# Altium schematic sheet 02_MSP430.SchDoc(02_MSP430)
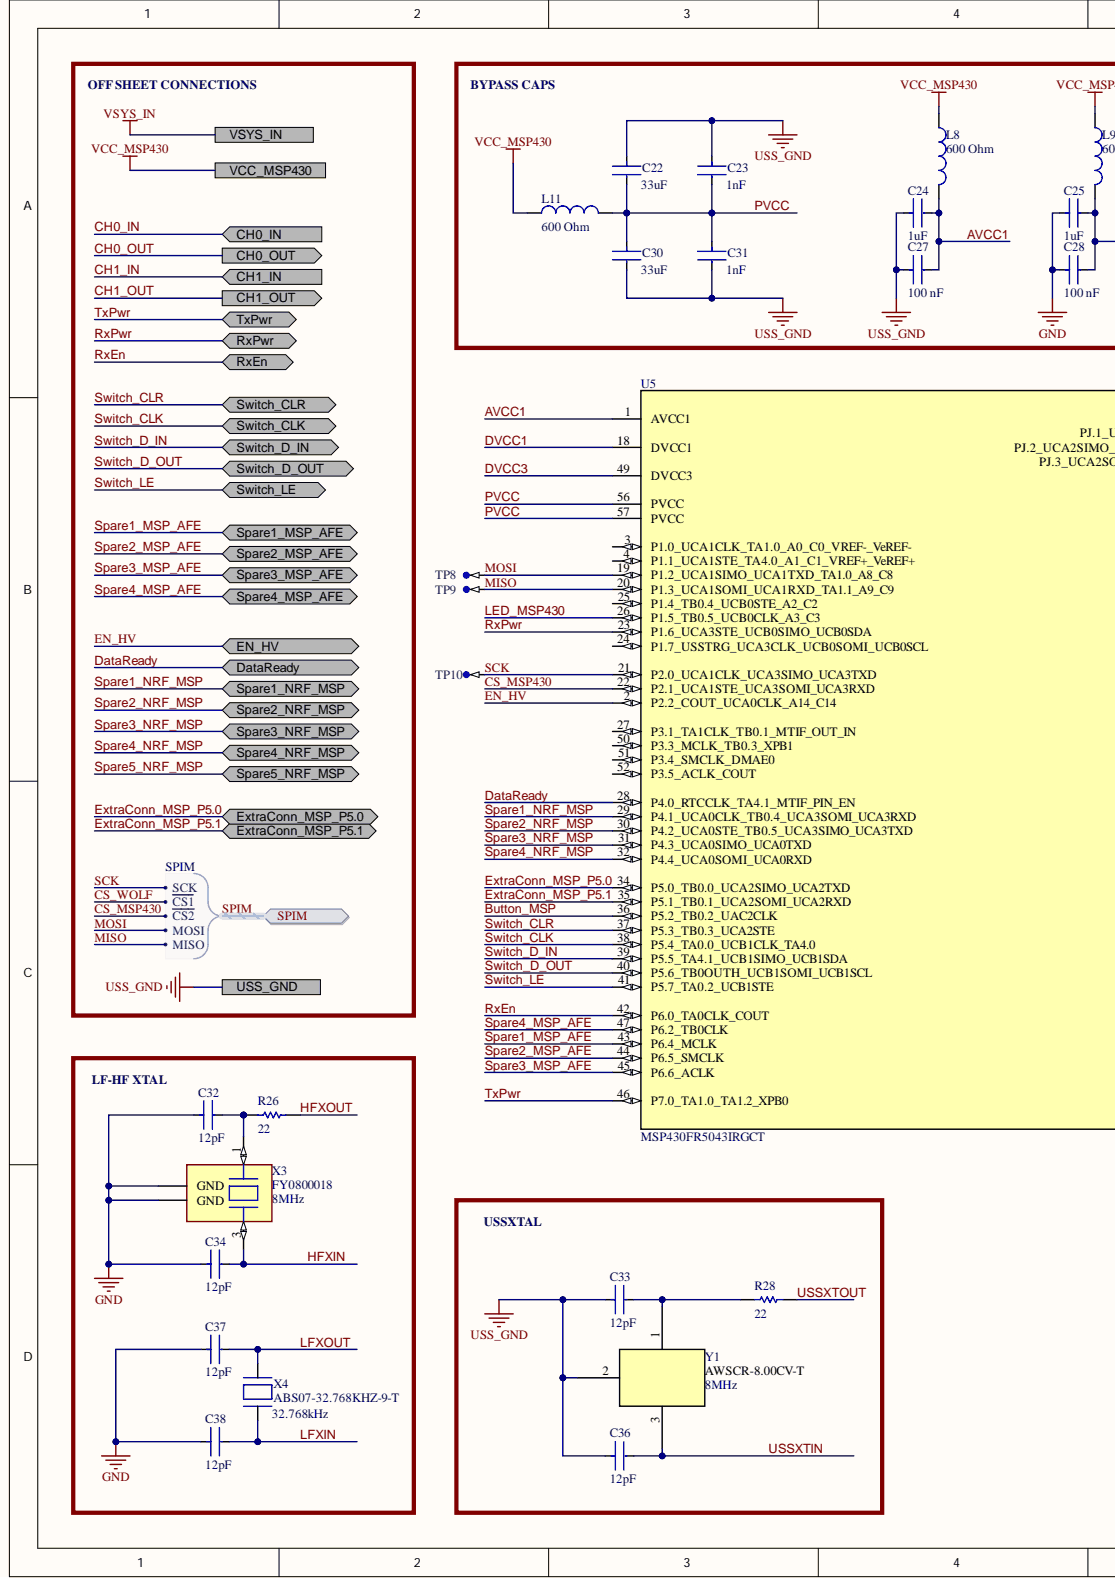
[diagram p0: 02_MSP430.SchDoc, left]
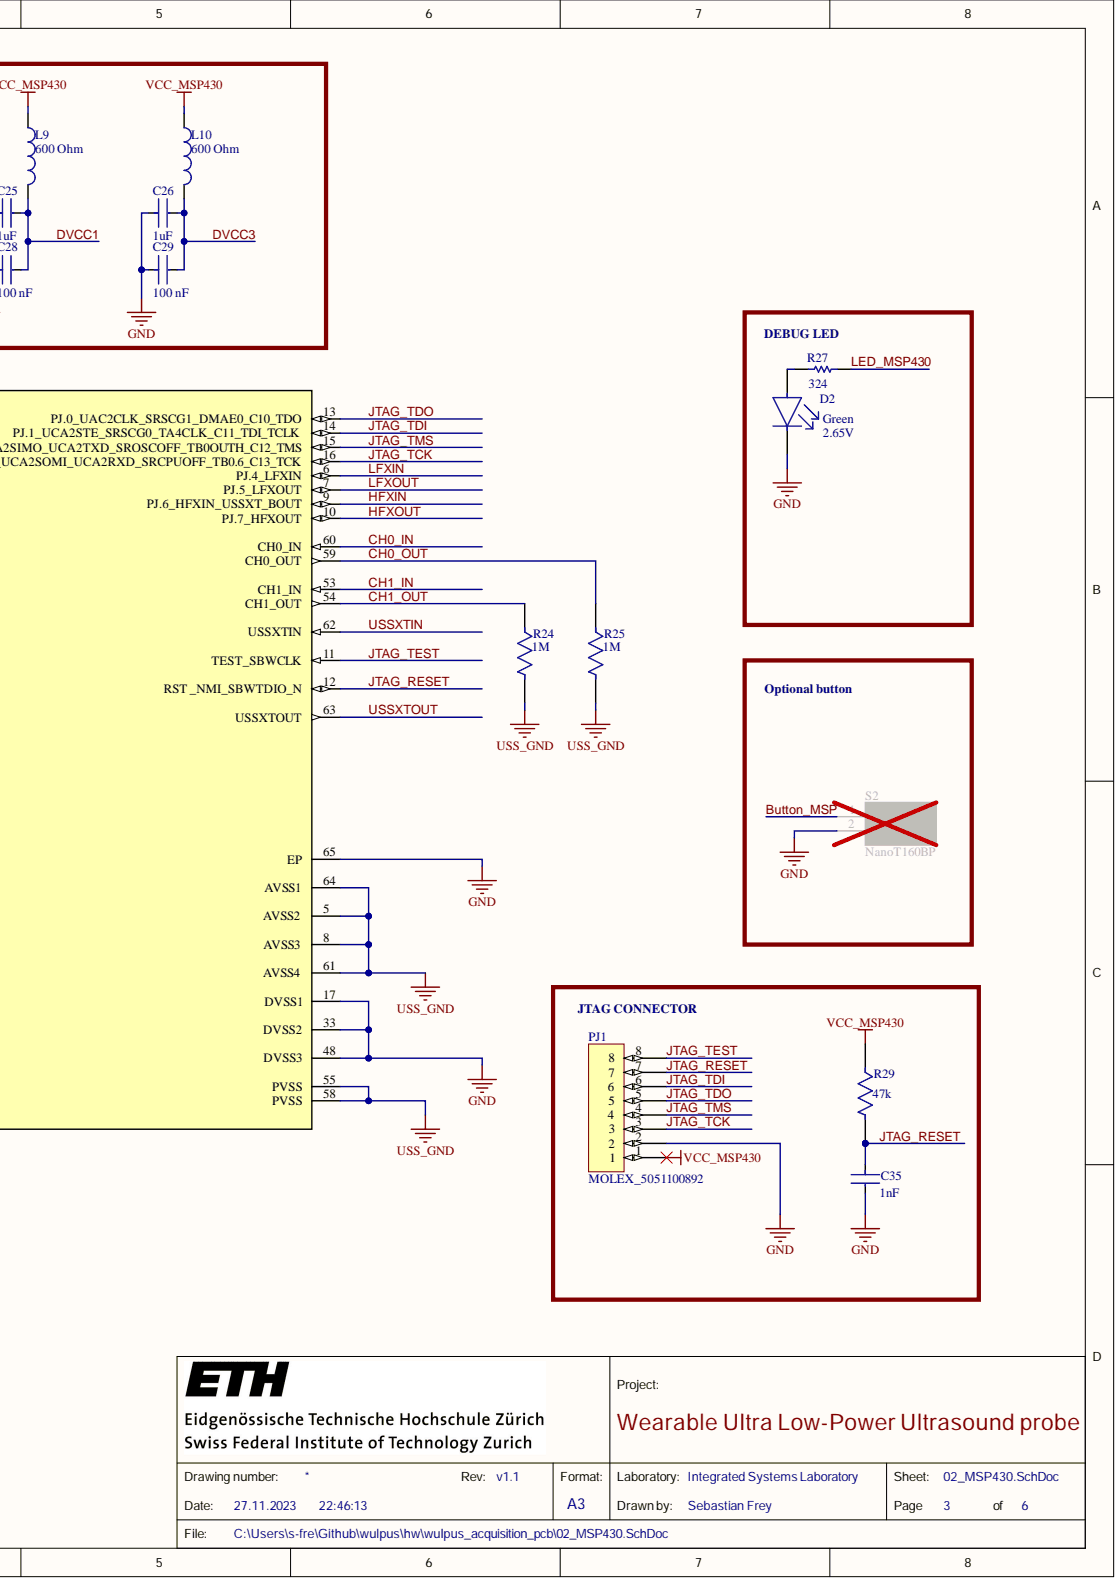
[diagram p1: 02_MSP430.SchDoc, right]

## Parts
C22  Capacitance: 33uF  [FP-C2012-125-0_2-IPC_A]  (hidden comment: C2012X5R1A336M125AC)
C23  Capacitance: 1nF  [FP-GRM155-0_05-IPC_B]  (hidden comment: GRM1555C1H102JA01D)
C24  C1608X7R1C105K080AC  [FP-C1608-080-0_1-IPC_B]  (value not drawn)
C25  C1608X7R1C105K080AC  [FP-C1608-080-0_1-IPC_B]  (value not drawn)
C26  C1608X7R1C105K080AC  [FP-C1608-080-0_1-IPC_B]  (value not drawn)
C27  value 100 nF  Capacitance: 100 nF  [FP-CC0402-MFG]  (hidden comment: CC0402KRX7R7BB104)
C28  value 100 nF  Capacitance: 100 nF  [FP-CC0402-MFG]  (hidden comment: CC0402KRX7R7BB104)
C29  value 100 nF  Capacitance: 100 nF  [FP-CC0402-MFG]  (hidden comment: CC0402KRX7R7BB104)
C30  Capacitance: 33uF  [FP-C2012-125-0_2-IPC_A]  (hidden comment: C2012X5R1A336M125AC)
C31  Capacitance: 1nF  [FP-GRM155-0_05-IPC_B]  (hidden comment: GRM1555C1H102JA01D)
C32  Capacitance: 12pF  [FP-GJM155-0_05-IPC_A]  (hidden comment: GJM1555C1H120GB01D)
C33  Capacitance: 12pF  [FP-GJM155-0_05-IPC_A]  (hidden comment: GJM1555C1H120GB01D)
C34  Capacitance: 12pF  [FP-GJM155-0_05-IPC_A]  (hidden comment: GJM1555C1H120GB01D)
C35  Capacitance: 1nF  [FP-GRM155-0_05-IPC_B]  (hidden comment: GRM1555C1H102JA01D)
C36  Capacitance: 12pF  [FP-GJM155-0_05-IPC_A]  (hidden comment: GJM1555C1H120GB01D)
C37  Capacitance: 12pF  [FP-GJM155-0_05-IPC_A]  (hidden comment: GJM1555C1H120GB01D)
C38  Capacitance: 12pF  [FP-GJM155-0_05-IPC_A]  (hidden comment: GJM1555C1H120GB01D)
D2  Color: Green  Forward Voltage: 2.65V  [FP-APHD1608LZGCK-MFG]  (hidden comment: APHD1608LZGCK)
L8  Impedance: 600 Ohm  [SMD-0805]  (hidden comment: 742792040)
L9  Impedance: 600 Ohm  [SMD-0805]  (hidden comment: 742792040)
L10  Impedance: 600 Ohm  [SMD-0805]  (hidden comment: 742792040)
L11  Impedance: 600 Ohm  [SMD-0805]  (hidden comment: 742792040)
PJ1  MOLEX_5051100892  [MOLEX_5051100892]
R24  Resistance: 1M  [FP-CRCW0402-e3-MFG]  (hidden comment: CRCW04021M00FKED)
R25  Resistance: 1M  [FP-CRCW0402-e3-MFG]  (hidden comment: CRCW04021M00FKED)
R26  RC0402JR-0722RL  [RESC1005X40X25NL05T10]  (value not drawn)
R27  CPF0402B324RE1  [RESC1005X35X20ML05T10]  (value not drawn)
R28  RC0402JR-0722RL  [RESC1005X40X25NL05T10]  (value not drawn)
R29  Resistance: 47k  [FP-CRCW0402-e3-IPC_C]  (hidden comment: CRCW040247K0FKED)
S2  NanoT160BP  [NanoT160BP]
TP8  TP-SM-C-100  [TP-SM-C-100]  (value not drawn)  (no BOM)
TP9  TP-SM-C-100  [TP-SM-C-100]  (value not drawn)  (no BOM)
TP10  TP-SM-C-100  [TP-SM-C-100]  (value not drawn)  (no BOM)
U5  MSP430FR5043IRGCT  [RGC0064G]
X3  FY0800018  Frequency: 8MHz  [XTAL_ECS-80-18-30B-AGN-TR]
X4  ABS07-32.768KHZ-9-T  Frequency: 32.768kHz  [XTAL320X150X90]
Y1  AWSCR-8.00CV-T  Frequency: 8MHz  [AWSCR-8.00CV-T_ABR]

## Ports
CH0_IN, CH0_OUT, CH1_IN, CH1_OUT, DataReady, EN_HV, ExtraConn_MSP_P5.0, ExtraConn_MSP_P5.1, RxEn, RxPwr, Spare1_MSP_AFE, Spare1_NRF_MSP, Spare2_MSP_AFE, Spare2_NRF_MSP, Spare3_MSP_AFE, Spare3_NRF_MSP, Spare4_MSP_AFE, Spare4_NRF_MSP, Spare5_NRF_MSP, SPIM, SPIM.MISO, SPIM.MOSI, SPIM.SCK, SPIM.~{CS1}, SPIM.~{CS2}, Switch_CLK, Switch_CLR, Switch_D_IN, Switch_D_OUT, Switch_LE, TxPwr, USS_GND, VCC_MSP430, VSYS_IN

## Nets
GND: C25.2 C26.2 C28.1 C29.1 C32.1 C34.1 C35.2 C37.1 C38.1 D2.1 PJ1.2 S2.2 U5.17(DVSS1) U5.33(DVSS2) U5.48(DVSS3) U5.65(EP) X3.2(GND) X3.4(GND)
AVCC1: C24.1 C27.2 L8.2 U5.1(AVCC1)
Button_MSP: S2.1 U5.36(P5.2_TB0.2_UAC2CLK)
CH0_IN: U5.60(CH0_IN) ; port CH0_IN
CH0_OUT: R25.1 U5.59(CH0_OUT) ; port CH0_OUT
CH1_IN: U5.53(CH1_IN) ; port CH1_IN
CH1_OUT: R24.1 U5.54(CH1_OUT) ; port CH1_OUT
CS_MSP430: U5.22(P2.1_UCA1STE_UCA3SOMI_UCA3RXD) ; port SPIM
DataReady: U5.28(P4.0_RTCCLK_TA4.1_MTIF_PIN_EN) ; port DataReady
DVCC1: C25.1 C28.2 L9.2 U5.18(DVCC1)
DVCC3: C26.1 C29.2 L10.2 U5.49(DVCC3)
EN_HV: U5.2(P2.2_COUT_UCA0CLK_A14_C14) ; port EN_HV
ExtraConn_MSP_P5.0: U5.34(P5.0_TB0.0_UCA2SIMO_UCA2TXD) ; port ExtraConn_MSP_P5.0
ExtraConn_MSP_P5.1: U5.35(P5.1_TB0.1_UCA2SOMI_UCA2RXD) ; port ExtraConn_MSP_P5.1
HFXIN: C34.2 U5.9(PJ.6_HFXIN_USSXT_BOUT) X3.3
HFXOUT: R26.2 U5.10(PJ.7_HFXOUT)
JTAG_RESET: C35.1 PJ1.7 R29.2 U5.12(RST__NMI_SBWTDIO_N)
JTAG_TCK: PJ1.3 U5.16(PJ.3_UCA2SOMI_UCA2RXD_SRCPUOFF_TB0.6_C13_TCK)
JTAG_TDI: PJ1.6 U5.14(PJ.1_UCA2STE_SRSCG0_TA4CLK_C11_TDI_TCLK)
JTAG_TDO: PJ1.5 U5.13(PJ.0_UAC2CLK_SRSCG1_DMAE0_C10_TDO)
JTAG_TEST: PJ1.8 U5.11(TEST_SBWCLK)
JTAG_TMS: PJ1.4 U5.15(PJ.2_UCA2SIMO_UCA2TXD_SROSCOFF_TB0OUTH_C12_TMS)
LED_MSP430: R27.1 U5.26(P1.5_TB0.5_UCB0CLK_A3_C3)
LFXIN: C38.2 U5.6(PJ.4_LFXIN) X4.1
LFXOUT: C37.2 U5.7(PJ.5_LFXOUT) X4.2
MISO: TP9.1 U5.20(P1.3_UCA1SOMI_UCA1RXD_TA1.1_A9_C9) ; port SPIM
MOSI: TP8.1 U5.19(P1.2_UCA1SIMO_UCA1TXD_TA1.0_A8_C8) ; port SPIM
PVCC: C22.2 C23.2 C30.1 C31.1 L11.2 U5.56(PVCC) U5.57(PVCC)
RxEn: U5.42(P6.0_TA0CLK_COUT) ; port RxEn
RxPwr: U5.23(P1.6_UCA3STE_UCB0SIMO_UCB0SDA) ; port RxPwr
SCK: TP10.1 U5.21(P2.0_UCA1CLK_UCA3SIMO_UCA3TXD) ; port SPIM
Spare1_MSP_AFE: U5.43(P6.4_MCLK) ; port Spare1_MSP_AFE
Spare1_NRF_MSP: U5.29(P4.1_UCA0CLK_TB0.4_UCA3SOMI_UCA3RXD) ; port Spare1_NRF_MSP
Spare2_MSP_AFE: U5.44(P6.5_SMCLK) ; port Spare2_MSP_AFE
Spare2_NRF_MSP: U5.30(P4.2_UCA0STE_TB0.5_UCA3SIMO_UCA3TXD) ; port Spare2_NRF_MSP
Spare3_MSP_AFE: U5.45(P6.6_ACLK) ; port Spare3_MSP_AFE
Spare3_NRF_MSP: U5.31(P4.3_UCA0SIMO_UCA0TXD) ; port Spare3_NRF_MSP
Spare4_MSP_AFE: U5.47(P6.2_TB0CLK) ; port Spare4_MSP_AFE
Spare4_NRF_MSP: U5.32(P4.4_UCA0SOMI_UCA0RXD) ; port Spare4_NRF_MSP
Switch_CLK: U5.38(P5.4_TA0.0_UCB1CLK_TA4.0) ; port Switch_CLK
Switch_CLR: U5.37(P5.3_TB0.3_UCA2STE) ; port Switch_CLR
Switch_D_IN: U5.39(P5.5_TA4.1_UCB1SIMO_UCB1SDA) ; port Switch_D_IN
Switch_D_OUT: U5.40(P5.6_TB0OUTH_UCB1SOMI_UCB1SCL) ; port Switch_D_OUT
Switch_LE: U5.41(P5.7_TA0.2_UCB1STE) ; port Switch_LE
TxPwr: U5.46(P7.0_TA1.0_TA1.2_XPB0) ; port TxPwr
USS_GND: C22.1 C23.1 C24.2 C27.1 C30.2 C31.2 C33.1 C36.1 R24.2 R25.2 U5.5(AVSS2) U5.8(AVSS3) U5.55(PVSS) U5.58(PVSS) U5.61(AVSS4) U5.64(AVSS1) Y1.2 ; port USS_GND
USSXTIN: C36.2 U5.62(USSXTIN) Y1.3
USSXTOUT: R28.2 U5.63(USSXTOUT)
VCC_MSP430: L8.1 L9.1 L10.1 L11.1 PJ1.1 R29.1 ; port VCC_MSP430
NetC32_2: C32.2 R26.1 X3.1
NetC33_2: C33.2 R28.1 Y1.1
NetD2_2: D2.2 R27.2
CS_WOLF: ; port SPIM
Spare5_NRF_MSP: ; port Spare5_NRF_MSP
VSYS_IN: ; port VSYS_IN

Not connected: U5 3(P1.0_UCA1CLK_TA1.0_A0_C0_VREF-_VeREF-) 4(P1.1_UCA1STE_TA4.0_A1_C1_VREF+_VeREF+) 24(P1.7_USSTRG_UCA3CLK_UCB0SOMI_UCB0SCL) 25(P1.4_TB0.4_UCB0STE_A2_C2) 27(P3.1_TA1CLK_TB0.1_MTIF_OUT_IN) 50(P3.3_MCLK_TB0.3_XPB1) 51(P3.4_SMCLK_DMAE0) 52(P3.5_ACLK_COUT)

## Library data of the parts
C22 C30 = CMP-08246-002720-1: Multilayer Ceramic Capacitors 33μF ±20% 10V X5R SMD 0805; Case Code (Imperial): 0805; Case Code (Metric): 2012; Case/Package: 0805; Component Kind: Standard; Depth: 1.25mm; Dielectric: X5R; Dielectric Material: Ceramic; Height: 1.25mm; Lead Free: Lead Free; Length: 2mm; Library Name: Altium Content Vault; Max Operating Temperature: 85°C; Min Operating Temperature: -55°C; Mount: Surface Mount; Package Quantity: 1; Packaging: Tape and Reel; REACH SVHC: No SVHC; Resistor Type: Ceramic; RoHS Compliant: Yes; Series: C; Termination: SMD/SMT; Thickness: 0.057inch; Tolerance: 20%; Voltage Rating: 10V; Voltage Rating (DC): 10V; Weight: 0.000194oz; Width: 0.049inch
C23 C31 C35 = CMP-2008-04254-2: Chip Multilayer Ceramic Capacitors for General Purpose, 0402, 1000pF, C0G, 30ppm/°C, 5%, 50V; Insulation Resistance: 10GR; Case Code (Imperial): 0402; Case Code (Metric): 1005; Case/Package: 0402; Component Kind: Standard; Depth: 0.5mm; Dielectric: C0G; Dielectric Material: Ceramic; Height: 0.5mm; Lead Free: Lead Free; Length: 1mm; Library Name: Altium Content Vault; Material: Ceramic; Max Operating Temperature: 125°C; Min Operating Temperature: -55°C; Mount: Surface Mount; Number of Pins: 2; Package Quantity: 1; Packaging: Tape and Reel; Radiation Hardening: No; REACH SVHC: No SVHC; Temperature Coefficient: 30ppm/°C; Termination: SMD/SMT; Thickness: 0.022inch; Tolerance: 5%; Voltage: 50V; Voltage Rating: 50V; Voltage Rating (DC): 50V; Width: 0.5mm
C24-C26 = CMP-08246-002727-1: Multilayer Ceramic Capacitors 1μF ±10% 16V X7R SMD 0603; Capacitance: 1uF; Case Code (Imperial): 0603; Case Code (Metric): 1608; Case/Package: 0603; Component Kind: Standard; Construction: Flat; Depth: 0.8mm; Dielectric: X7R; Dielectric Material: Ceramic; Height: 0.8mm; Lead Free: Lead Free; Length: 1.6mm; Library Name: Altium Content Vault; Max Operating Temperature: 125°C; Min Operating Temperature: -55°C; Mount: Surface Mount; Package Quantity: 1; Packaging: Tape and Reel; Radiation Hardening: No; REACH SVHC: No SVHC; Resistor Type: Ceramic; RoHS Compliant: Yes; Series: C; Termination: SMD/SMT; Thickness: 0.037inch; Tolerance: 10%; Voltage: 16V; Voltage Rating: 16V; Voltage Rating (DC): 16V; Weight: 7.1E-05oz; Width: 0.031inch
C27-C29 = CMP-03422-000048-1: CAP CER 0.1UF 16V X7R 0402; Manufacturer: YAGEO; Automotive Grade: NA; Material: Ceramic; Temperature Coefficient: 30ppm/°C; Tolerance: 0.1; Automotive: No; Category: Cap; Component Kind: Standard; ComponentLink2Description: Datasheet; ComponentLink2URL: http://www.yageo.com/documents/recent/UPY-GP_NP0_16V-to-50V_16.pdf; Device Class L1: Passive Components; Device Class L2: Capacitors; Device Class L3: Ceramic Capacitors; Digikey Description: CAP CER 0.1UF 16V X7R 0402; Footprint Url: http://www.yageo.com/exep/pages/download/literatures/UPY-C_GEN_24.pdf; Height: 0.55mm; Ipc Land Pattern Name: CAPC10050X50; Lead Free: Yes; Library Name: Altium Content Vault; Manufacturer Part Number: CC0402KRX7R7BB104; Mouser Description: 0402 0.1 uF 16 V ±10 % Tolerance X7R SMT Multilayer Ceramic Capacitor; Octopart: https://octopart.com/cc0402krx7r7bb104-yageo-42244624; Package: CC0402; RoHS: TRUE; Temperature: -55°C to +125°C; Temperature Characteristic: X7R; Temperature Range High: +125°C; Temperature Range Low: -55°C; Voltage Rating: 16 V
C32-C34 C36-C38 = CMP-2008-03799-2: Multi-Layer Ceramic Capacitor 12pF C0G  ±2% 0402 Paper T/R; Voltage Rating: 50V; Case Code (Imperial): 0402; Case Code (Metric): 1005; Case/Package: 0402; Component Kind: Standard; Depth: 0.5mm; Dielectric: C0G; Height: 0.5mm; Lead Free: Lead Free; Length: 1mm; Library Name: Altium Content Vault; Max Operating Temperature: 125°C; Min Operating Temperature: -55°C; Mount: Surface Mount; Package Quantity: 10000; Packaging: Tape and Reel; Radiation Hardening: No; Termination: SMD/SMT; Thickness: 0.022inch; Tolerance: 2%; Voltage Rating (DC): 50V; Width: 0.5mm
D2 = CMP-44529-000045-1: LED GREEN CLEAR 1608 SMD; Dominant Wavelength: 525nm; Lens Color: Colorless; Lens Size: 0.7mm; Lens Style: Round; Luminous Intensity: 180mcd; Test Current: 2mA; Viewing Angle: 100°; Case Code (Imperial): 0603; Case Code (Metric): 1608; Case/Package: 0603; Component Kind: Standard; Height: 0.75mm; Length: 1.6mm; Lens Transparency: Clear; Library Name: Altium Content Vault; Mount: Surface Mount; Packaging: Tape and Reel; Width: 0.8mm
L8-L11 = CMP-0220-00032-1: SMD EMI Suppression Ferrite Bead WE-CBF, Z = 600 Ohm; Component Kind: Standard; ComponentLink1Description: Manufacturer Link; ComponentLink1URL: http://www.we-online.com; ComponentLink2Description: Datasheet; ComponentLink2URL: http://www.we-online.de/katalog/media/pdf/742792040.pdf; DatasheetVersion: Dec-2005; Library Name: Altium Content Vault; PackageDescription: Chip Inductor, 2-Leads, Body 2.0 x 1.2 mm; PackageReference: SMD-0805; PackageVersion: 2005
R24 R25 = CMP-2002-08314-2: RES Thick Film, 1MΩ, 1%, 0.063W, 100ppm/°C, 0402; Max Power Dissipation: 63mW; Voltage Rating: 50V; Case Code (Imperial): 0402; Case Code (Metric): 1005; Case/Package: 0402; Component Kind: Standard; Composition: Thick Film; Contact Plating: Tin; Depth: 0.5mm; Features: Automotive AEC-Q200; Height: 0.35mm; Lead Free: Lead Free; Length: 1mm; Library Name: Altium Content Vault; Max Operating Temperature: 155°C; Military Standard: Not; Min Operating Temperature: -55°C; Number of Pins: 2; Number of Terminations: 2; Package Quantity: 10; Packaging: Tape and Reel; Power Rating: 63mW; Radiation Hardening: No; Temperature Coefficient: 100ppm/°C; Termination: SMD/SMT; Tolerance: 1%; Voltage Rating (DC): 50V; Weight: 2.3E-05oz; Width: 0.02inch
R26 R28 = CMP-2002-07961-1: Manufacturer: Yageo / Phycomp; Resistance: 22; Component Kind: Standard; Library Name: Altium Content Vault; Part Number: RC0402JR-0722RL
R27 = CMP-2002-03257-1: Manufacturer: TE Connectivity / AMP; Resistance: 324; Component Kind: Standard; Library Name: Altium Content Vault; Part Number: CPF0402B324RE1
R29 = CMP-02407-007705-1: RES Thick Film, 47kΩ, 1%, 0.063W, 100ppm/°C, 0402; Power Rating: 63mW; Voltage Rating: 50V; Case Code (Imperial): 0402; Case Code (Metric): 1005; Case/Package: 0402; Component Kind: Standard; Composition: Thick Film; Contact Plating: Tin; Depth: 0.5mm; Height: 0.35mm; Lead Free: Lead Free; Length: 1mm; Library Name: Altium Content Vault; Max Operating Temperature: 155°C; Military Standard: Not; Min Operating Temperature: -55°C; Mount: Surface Mount; Number of Terminations: 2; Package Quantity: 10000; Packaging: Tape and Reel; Radiation Hardening: No; Temperature Coefficient: 100ppm/°C; Termination: SMD/SMT; Tolerance: 1%; Voltage Rating (DC): 50V; Weight: 2.3E-05oz; Width: 0.5mm; Working Voltage: 50V
S2 = NanoT160BP: Switch; Manufacturer_Name: LITTELFUSE; Component Kind: Standard; Datasheet Link: https://www.ckswitches.com/media/2866/nanot.pdf; Height: 1mm; Library Name: Schlib1.SchLib; Manufacturer_Part_Number: NanoT160BP
TP8-TP10 = CMP-0484-00002-1: Test Point, Surface Mount, Circular, Pad Diameter 1 mm; Library Name: Altium Content Vault
U5 = MSP430FR5043IRGCT: No Description Available; Mfr_Name: Texas Instruments; Component Kind: Standard; Library Name: Schlib1.SchLib; Manufacturer_Part_Number: MSP430FR5043IRGCT; RefDes: RefDes; Type: DEV; Copyright: Copyright (C) 2021 Ultra Librarian. All rights reserved.
X3 = FY0800018: Crystal 8MHz ±30ppm (Tol) ±30ppm (Stability) 18pF FUND 100Ohm 4-Pin SMD T/R; Manufacturer: Diodes; ESR (Equivalent Series Resistance): 100R; Case/Package: SMD/SMT; Category: Crystals; Component Kind: Standard; ComponentLink1Description: http://media.digikey.com/pdf/Data%20Sheets/Pericom%20PDFs/FY0800018_VerB.pdf?src-supplier=Digi-Key; ComponentLink1URL: http://media.digikey.com/pdf/Data%20Sheets/Pericom%20PDFs/FY0800018_VerB.pdf?src-supplier=Digi-Key; Frequency Stability: 30ppm; Frequency Tolerance: 30ppm; Height: 0.043inch; Length: 0.197inch; Library Name: Schlib1.SchLib; Load Capacitance: 18pF; Manufacturer Part Number: FY0800018; Max Operating Temperature: 85°C; Min Operating Temperature: -40°C; Mount: Surface Mount; Packaging: Cut Tape; Pricing 1: 1=0.80000, 10=0.70800, 50=0.66220, 100=0.58520, 500=0.55440 (USD); RoHS: Yes; Stock 1: 10869; Supplier 1: Digi-Key; Supplier Part Number 1: FY0800018CT-ND; Width: 0.126inch
X4 = ABS07-32.768KHZ-9-T: Crystal; Miniature; 3.2 x 1.5 x 0.9mm; 32.768KHZ; 9pf; 20ppm -40+85C; SMD; T/R; Manufacturer: Abracon; ESR (Equivalent Series Resistance): 70kR; Insulation Resistance: 500MR; Case/Package: CSMD; Category: Crystals; Component Kind: Standard; ComponentLink1Description: https://datasheet.ciiva.com/855/abs07-855948.pdf?src-supplier=Digi-Key; ComponentLink1URL: https://datasheet.ciiva.com/855/abs07-855948.pdf?src-supplier=Digi-Key; Depth: 1.5mm; Frequency Stability: 20ppm; Frequency Tolerance: 20ppm; Height: 0.035inch; Lead Free: Lead Free; Length: 3.2mm; Library Name: Schlib1.SchLib; Load Capacitance: 9pF; Manufacturer Part Number: ABS07-32.768KHZ-9-T; Max Operating Temperature: 85°C; Min Operating Temperature: -40°C; Mount: Surface Mount; Number of Pins: 2; Operating Frequency: 32.768 kHz; Package Quantity: 3000; Packaging: Tape and Reel; Pricing 1: 1=1.36000, 10=1.30000, 50=1.27000, 100=1.08860, 500=1.05840, 1000=0.89208 (USD); Radiation Hardening: No; REACH SVHC: No SVHC; RoHS: Yes; RoHS Compliant: Yes; Series: ABS07; Series Resistance: 70kR; Stock 1: 83876; Supplier 1: Digi-Key; Supplier Part Number 1: 535-9544-1-ND; Termination: SMD/SMT; Tolerance: 20ppm; Width: 1.5mm
Y1 = AWSCR-8.00CV-T: No Description Available; Mfr_Name: Abracon; Component Kind: Standard; Library Name: Schlib1.SchLib; Manufacturer_Part_Number: AWSCR-8.00CV-T; RefDes: RefDes; Type: DEV; Copyright: Copyright (C) 2021 Ultra Librarian. All rights reserved.
PJ1 = MOLEX_5051100892: Component Kind: Standard; Library Name: Schlib1.SchLib
